# Altium schematic sheet LEGO_VL53L1X_Sensor.SchDoc(LEGO_VL53L1X_Sensor)
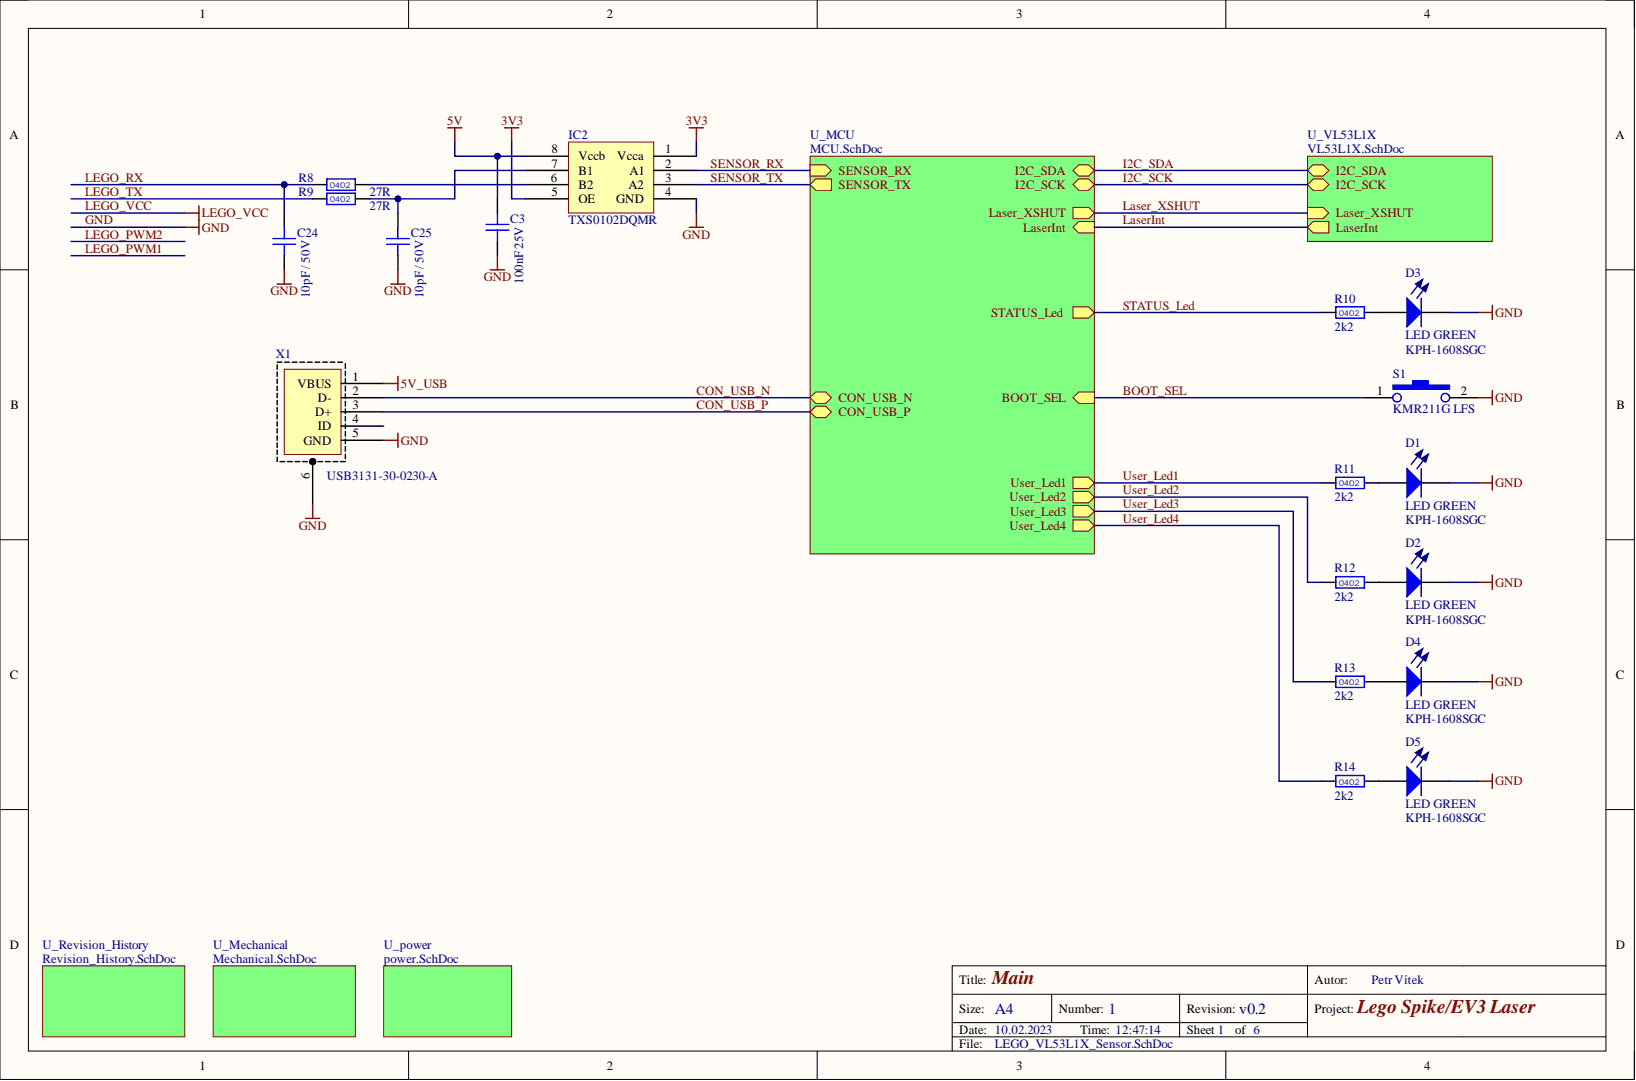
[diagram p0: LEGO_VL53L1X_Sensor.SchDoc]

## Parts
C3  value 100nF 25V  [C0402]  (hidden comment: UniversalCapacitor)
C24  value 10pF / 50V  [C0402]  (hidden comment: UniversalCapacitor)
C25  value 10pF / 50V  [C0402]  (hidden comment: UniversalCapacitor)
D1  LED GREEN  schematic_name: LED  [LED0603]
D2  LED GREEN  value KPH-1608SGC  schematic_name: LED  [LED0603]
D3  LED GREEN  value KPH-1608SGC  schematic_name: LED  [LED0603]
D4  LED GREEN  value KPH-1608SGC  schematic_name: LED  [LED0603]
D5  LED GREEN  value KPH-1608SGC  schematic_name: LED  [LED0603]
IC2  TXS0102DQMR  [X2SON-8_1.8x1.2]
R8  value 27R  [R0402]  (hidden comment: UniversalResistor0402)
R9  value 27R  [R0402]  (hidden comment: UniversalResistor0402)
R10  value 2k2  [R0402]  (hidden comment: UniversalResistor0402)
R11  value 2k2  [R0402]  (hidden comment: UniversalResistor0402)
R12  value 2k2  [R0402]  (hidden comment: UniversalResistor0402)
R13  value 2k2  [R0402]  (hidden comment: UniversalResistor0402)
R14  value 2k2  [R0402]  (hidden comment: UniversalResistor0402)
S1  value KMR211G LFS  [ButtonKMR2]  (hidden comment: PushButton)
X1  USB  value USB3131-30-0230-A  [USB3131-XX-0230-X]

## Nets
3V3: IC2.1(Vcca) IC2.5(OE)
5V: C3.2 IC2.8(Vccb)
5V_USB: X1.1(VBUS)
BOOT_SEL: S1.1
CON_USB_N: X1.2(D-)
CON_USB_P: X1.3(D+)
GND: C3.1 C24.2 C25.2 D1.2 D2.2 D3.2 D4.2 D5.2 IC2.4(GND) S1.2 X1.5(GND) X1.6
LEGO_RX: C24.1 R8.2
LEGO_TX: R9.2
SENSOR_RX: IC2.2(A1)
SENSOR_TX: IC2.3(A2)
STATUS_Led: R10.2
User_Led1: R11.2
User_Led2: R12.2
User_Led3: R13.2
User_Led4: R14.2
NetC25_1: C25.1 IC2.7(B1) R9.1
NetD1_1: D1.1 R11.1
NetD2_1: D2.1 R12.1
NetD3_1: D3.1 R10.1
NetD4_1: D4.1 R13.1
NetD5_1: D5.1 R14.1
NetIC2_6: IC2.6(B2) R8.1
NetX1_4: X1.4(ID)
I2C_SCK:
I2C_SDA:
Laser_XSHUT:
LaserInt:
LEGO_PWM1:
LEGO_PWM2:
LEGO_VCC:

## Library data of the parts
C3 = 0402B104K250CT: WALSIN - 0402B104K250CT - CAPACITOR, MLCC, X7R, 0.1UF, 25V, 0402; part_id: 2099; Database Table Name: capacitors_ceramic_0402; Component Kind: Standard; ComponentLink1URL: http://cz.farnell.com/2496772?Ntt=2496772; footprint_name: C0402; HelpURL: http://www.farnell.com/datasheets/2340522.pdf; name: 0402B104K250CT; schematic_name: UniversalCapacitor; Supplier 1: Farnell; Supplier Part Number 1: 2496772; Library Name: UTBStockLib.SVNDbLib
C24 C25 = C0402C100D5GAC: Kategorie produktu:	Vícevrstvé keramické kondenzátory MLCC – pro povrchovou montáž SMD, SMT	  Výrobce:	KEMET	  RoHS::	V souladu RoHS Údaje	  Série:	C-C	  Kapacita:	10 pF	  Jmenovité napětí (DC) stejnosměrného proudu	:	50 VDC	  Dielektrikum:	C0G (NP0)	  Tolerance:	0.5 pF	  Kód pouzdra - palce:	0402	  Kód pouzdra - mm:	1005	  Výška:	-	  Maximální provozní teplota:	+ 125 C	  Minimální provozní teplota:	- 55 C	  Koncovka:	-	  Výrobek:	General Type MLCCs	  Obal:	Cut Tape	  Obal:	MouseReel	  Obal:	Ree; part_id: 2258; Database Table Name: capacitors_ceramic_0402; Component Kind: Standard; ComponentLink1URL: https://cz.mouser.com/ProductDetail/KEMET/C0402C100D5GAC/?qs=sGAEpiMZZMu0f%252bT2bkVfuj0aSb%252bMTiHU; footprint_name: C0402; HelpURL: http://www.mouser.com/ds/2/212/KEM_C1003_C0G_SMD-1101588.pdf; name: C0402C100D5GAC; schematic_name: UniversalCapacitor; Supplier 1: Mouser; Supplier Part Number 1: 80-C0402C100D5GAC; Library Name: UTBStockLib.SVNDbLib
D1-D5 = KPH-1608SGC: KINGBRIGHT - KPH-1608SGC - LED, GREEN, 12MCD, 568NM, SMD LED 0603 zelená; part_id: 980; Database Table Name: diodes; Component Kind: Standard; ComponentLink1URL: http://cz.farnell.com/2426215?Ntt=2426215; footprint_name: LED0603; HelpURL: http://www.farnell.com/datasheets/1821279.pdf; name: KPH-1608SGC; Supplier 1: Farnell; Supplier Part Number 1: 2426215; Library Name: UTBStockLib.SVNDbLib
  D1 D3: Value: KPH-1608SGC
IC2 = TXS0102DQMR: TEXAS INSTRUMENTS - TXS0102DQMR - Voltage Level Translator, 2 Inputs, 4.2ns, 1.65V to 5.5V, X2SON-8; part_id: 2969; Database Table Name: other_integrated; Component Kind: Standard; ComponentLink1URL: http://cz.farnell.com/3050430?Ntt=3050430; footprint_name: X2SON-8_1.8x1.2; name: TXS0102DQMR; schematic_name: TXS0102DQMR; Supplier 1: Farnell; Supplier Part Number 1: 3050430; Value: TXS0102DQMR; Library Name: UTBStockLib.SVNDbLib
R8 R9 = CRCW040227R0FKED: VISHAY - CRCW040227R0FKED. - SMD Chip Resistor, 27 ohm, ± 1%, 62.5 mW, 0402 [1005 Metric], Thick Film, General Purpose; Database Table Name: resistors_0402; Component Kind: Standard; ComponentLink1URL: http://cz.farnell.com/2140614?Ntt=2140614; HelpURL: http://www.farnell.com/datasheets/2310790.pdf; name: CRCW040227R0FKED; Supplier 1: Farnell; Supplier Part Number 1: 2140614; Library Name: UTBStockLib.SVNDbLib
R10-R14 = CRCW04022K20FKED: VISHAY - CRCW04022K20FKED. - RES, THICK FILM, 2K2, 1%, 0.063W, 0402; Database Table Name: resistors_0402; Component Kind: Standard; ComponentLink1URL: http://cz.farnell.com/2140802?Ntt=2140802; HelpURL: http://www.farnell.com/datasheets/2310790.pdf; name: CRCW04022K20FKED; Supplier 1: Farnell; Supplier Part Number 1: 2140802; Library Name: UTBStockLib.SVNDbLib
S1 = KMR211G LFS: C & K COMPONENTS - KMR211G LFS - SWITCH, SPST, 0.05A, 32VDC, SMD; part_id: 1256; Database Table Name: other; Component Kind: Standard; ComponentLink1URL: http://cz.farnell.com/1437635?Ntt=1437635; footprint_name: ButtonKMR2; HelpURL: http://www.farnell.com/datasheets/1697814.pdf; name: KMR211G LFS; schematic_name: PushButton; Supplier 1: Farnell; Supplier Part Number 1: 1437635; Library Name: UTBStockLib.SVNDbLib
X1 = USB3131-30-0230-A: GCT (GLOBAL CONNECTOR TECHNOLOGY) - USB3131-30-0230-A - USB Connector, Micro USB Type B, USB 2.0, Receptacle, 5 Ways, Through Hole Mount, Vertical; part_id: 2968; Database Table Name: connectors; schematic_name: MUSB; Component Kind: Standard; ComponentLink1Description: http://www.farnell.com/datasheets/1359588.pdf; ComponentLink1URL: http://cz.farnell.com/2537022?Ntt=2537022; ComponentLink2Description: http://www.adam-tech.com/rick/FinalSingle/CatPg133-134.pdf; ComponentLink2URL: http://www.adam-tech.com/rick/FinalSingle/CatPg133-134.pdf; footprint_name: USB3131-XX-0230-X; HelpURL: http://www.farnell.com/datasheets/2342944.pdf; name: USB3131-30-0230-A; Pricing: 1=20,954, 100=15,959, 250=14,315, 500=13,066, 1000=12,031 (EUR); Supplier 1: Farnell; Supplier Part Number 1: 2537022; Library Name: UTBStockLib.SVNDbLib
